# Altium schematic sheet MCU.SchDoc(MCU)
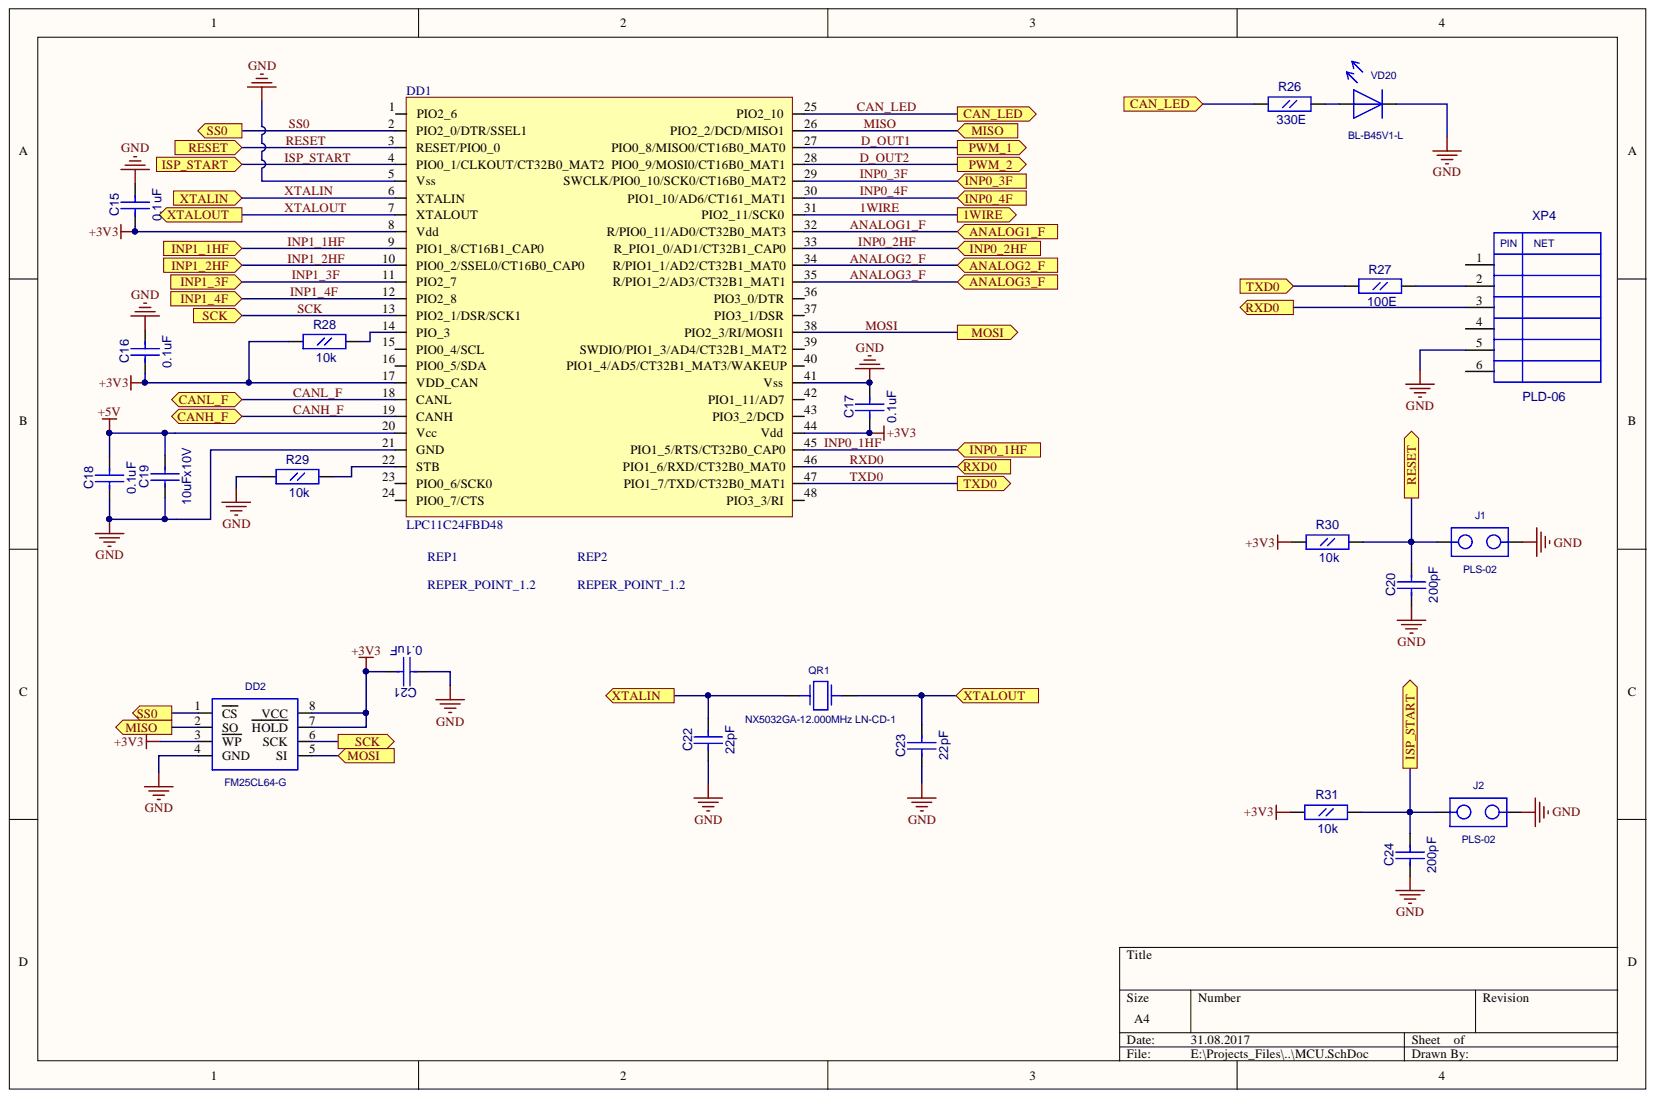
[diagram p0: MCU.SchDoc]

## Parts
C15  0.1uF  [CC0603]
C16  0.1uF  [CC0603]
C17  0.1uF  [CC0603]
C18  0.1uF  [CC0603]
C19  10uFx10V  [CC0805]
C20  200pF  [CC0603]
C21  0.1uF  [CC0603-3M]
C22  22pF  [CC0603]
C23  22pF  [CC0603]
C24  200pF  [CC0603]
DD1  LPC11C24FBD48  [LQFP-48]
DD2  FM25CL64-G  [SO-8]
J1  PLS-02  [PLS-02]
J2  PLS-02  [PLS-02]
QR1  NX5032GA-12.000MHz LN-CD-1  [AC5032_2]
R26  330E  [RC0603]
R27  100E  [RC0603]
R28  10k  [RC0603]
R29  10k  [RC0603]
R30  10k  [RC0603]
R31  10k  [RC0603]
REP1  REPER_POINT_1.2  [REPER1.2]
REP2  REPER_POINT_1.2  [REPER1.2]
VD20  BL-B45V1-L  [LED3]
XP4  PLD-06  [PIN6]

## Ports
1WIRE, ANALOG1_F, ANALOG2_F, ANALOG3_F, CAN_LED, CANH_F, CANL_F, INP0_1HF, INP0_2HF, INP0_3F, INP0_4F, INP1_1HF, INP1_2HF, INP1_3F, INP1_4F, ISP_START, MISO, MOSI, PWM_1, PWM_2, RESET, RXD0, SCK, SS0, TXD0, XTALIN, XTALOUT

## Nets
+3V3: C15.1 C16.1 C17.1 C21.2 DD1.8(Vdd) DD1.17(VDD_CAN) DD1.44(Vdd) DD2.3(WP) DD2.7(HOLD) DD2.8(VCC) R28.1 R30.1 R31.1
+5V: C18.2 C19.2 DD1.20(Vcc)
GND: C15.2 C16.2 C17.2 C18.1 C19.1 C20.1 C21.1 C22.1 C23.1 C24.1 DD1.5(Vss) DD1.21(GND) DD1.41(Vss) DD2.4(GND) J1.2 J2.2 R29.1 VD20.1 XP4.5
1WIRE: DD1.31(PIO2_11/SCK0) ; port 1WIRE
ANALOG1_F: DD1.32(R/PIO0_11/AD0/CT32B0_MAT3) ; port ANALOG1_F
ANALOG2_F: DD1.34(R/PIO1_1/AD2/CT32B1_MAT0) ; port ANALOG2_F
ANALOG3_F: DD1.35(R/PIO1_2/AD3/CT32B1_MAT1) ; port ANALOG3_F
CAN_LED: DD1.25(PIO2_10) R26.1 ; port CAN_LED
CANH_F: DD1.19(CANH) ; port CANH_F
CANL_F: DD1.18(CANL) ; port CANL_F
D_OUT1: DD1.27 ; port PWM_1
D_OUT2: DD1.28 ; port PWM_2
INP0_1HF: DD1.45(PIO1_5/RTS/CT32B0_CAP0) ; port INP0_1HF
INP0_2HF: DD1.33(R_PIO1_0/AD1/CT32B1_CAP0) ; port INP0_2HF
INP0_3F: DD1.29(SWCLK/PIO0_10/SCK0/CT16B0_MAT2) ; port INP0_3F
INP0_4F: DD1.30(PIO1_10/AD6/CT161_MAT1) ; port INP0_4F
INP1_1HF: DD1.9(PIO1_8/CT16B1_CAP0) ; port INP1_1HF
INP1_2HF: DD1.10 ; port INP1_2HF
INP1_3F: DD1.11(PIO2_7) ; port INP1_3F
INP1_4F: DD1.12(PIO2_8) ; port INP1_4F
ISP_START: C24.2 DD1.4 J2.1 R31.2 ; port ISP_START
MISO: DD1.26(PIO2_2/DCD/MISO1) DD2.2(SO) ; port MISO
MOSI: DD1.38(PIO2_3/RI/MOSI1) DD2.5(SI) ; port MOSI
RESET: C20.2 DD1.3(RESET/PIO0_0) J1.1 R30.2 ; port RESET
RXD0: DD1.46(PIO1_6/RXD/CT32B0_MAT0) XP4.3 ; port RXD0
SCK: DD1.13(PIO2_1/DSR/SCK1) DD2.6(SCK) ; port SCK
SS0: DD1.2(PIO2_0/DTR/SSEL1) DD2.1(CS) ; port SS0
TXD0: DD1.47(PIO1_7/TXD/CT32B0_MAT1) R27.1 ; port TXD0
XTALIN: C22.2 DD1.6(XTALIN) QR1.1 ; port XTALIN
XTALOUT: C23.2 DD1.7(XTALOUT) QR1.2 ; port XTALOUT
NetDD1_14: DD1.14(PIO_3) R28.2
NetDD1_22: DD1.22(STB) R29.2
NetR26_2: R26.2 VD20.2
NetR27_2: R27.2 XP4.2

Not connected: DD1 1(PIO2_6) 15 16 23 24 36(PIO3_0/DTR) 37(PIO3_1/DSR) 39(SWDIO/PIO1_3/AD4/CT32B1_MAT2) 40(PIO1_4/AD5/CT32B1_MAT3/WAKEUP) 42(PIO1_11/AD7) 43(PIO3_2/DCD) 48(PIO3_3/RI); XP4 1 4 6

## Library data of the parts
C15-C18 C20-C24 = CC0603: Description: CC0603; Component Kind: Standard
  C15-C18 C21: Value: 0.1uF
  C20 C24: Value: 200pF
  C22 C23: Value: 22pF
  C15-C18 C20 C22-C24: Library Name: gprs_modem_2.SchLib
  C21: Library Name: gprs_modem2.SchLib
C19 = CC0805: Value: 10uFx10V; Description: CC0805; Component Kind: Standard; Library Name: gprs_modem_2.SchLib
QR1 = AC5032_2: AC5032/2; Value: NX5032GA-12.000MHz LN-CD-1; Component Kind: Standard; Library Name: gprs_modem_2.SchLib
R26-R31 = RC0603: Description: RC0603; Component Kind: Standard; Library Name: gprs_modem_2.SchLib
  R26: Value: 330E
  R27: Value: 100E
  R28-R31: Value: 10k
REP1 REP2 = REPER1.2: Value: REPER_POINT_1.2; Description: REPER1.2; Component Kind: Standard; Library Name: gprs_modem_2.SchLib
VD20 = LED_3: Value: BL-B45V1-L; Description: LED_3; Component Kind: Standard; Library Name: gprs_modem_2.SchLib
XP4 = PLD-06: PLD06; Component Kind: Standard; Library Name: gprs_modem_2.SchLib
DD1 = LPC11C24FBD48: Value: LPC11C24FBD48; Component Kind: Standard; Library Name: gprs_modem_2.SchLib
DD2 = FM25CL64-G: Description: FM25CL64-G; Component Kind: Standard; Library Name: gprs_modem_2.SchLib
J1 J2 = PLS-02: Description: PLS-02; Component Kind: Standard; Library Name: gprs_modem_2.SchLib
